# Altium schematic sheet [02] - Connectors.SchDoc("[02] - Connectors")
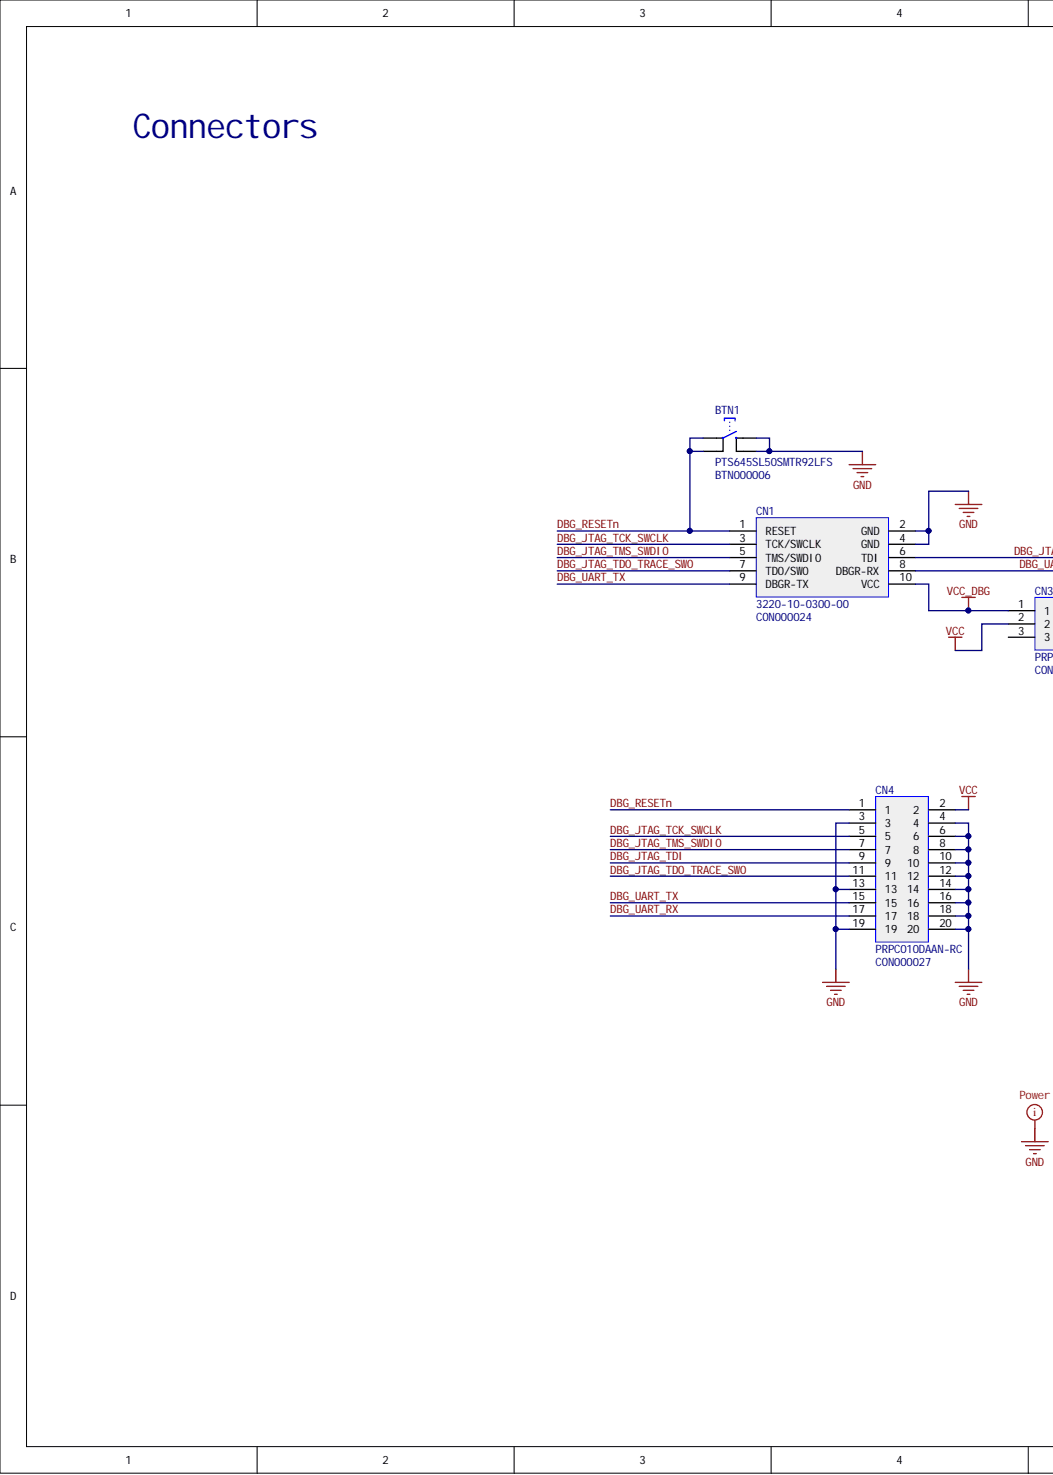
[diagram p0: [02] - Connectors.SchDoc, left]
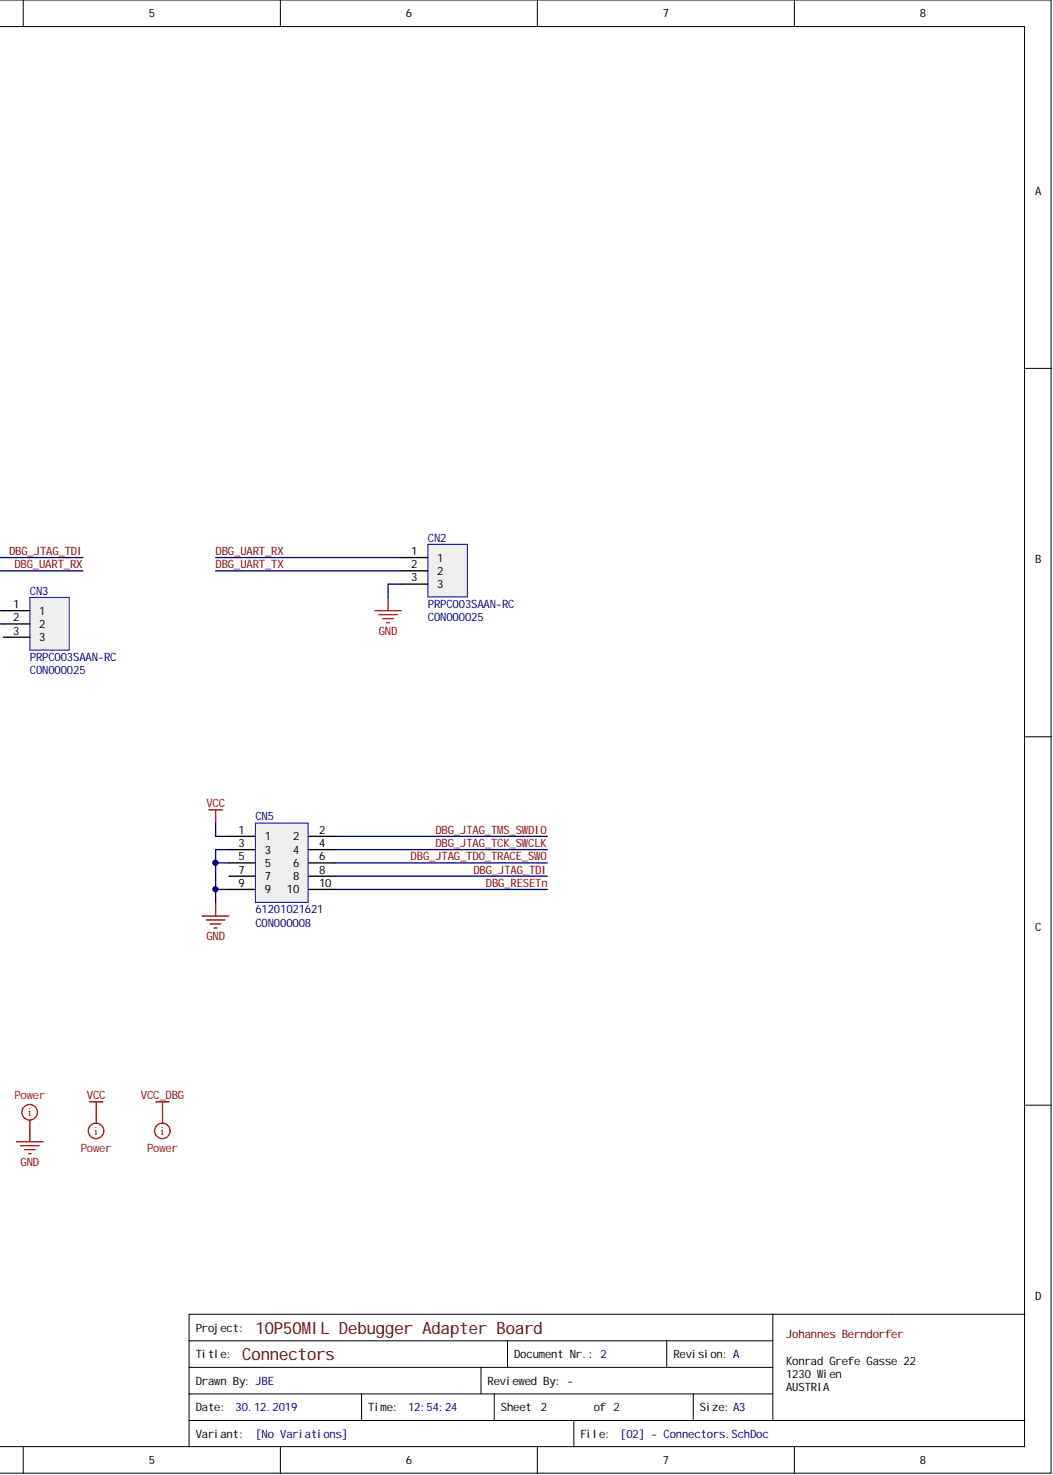
[diagram p1: [02] - Connectors.SchDoc, right]

## Parts
BTN1  PTS645SL50SMTR92LFS  Internal Part Number: BTN000006  [BTN-SMD-PTS645-CK]
CN1  3220-10-0300-00  [CON-SMD-PINHEADER-SHIELDED-1.27MM-10POS-2X5-3220-10-0300-00-CNC-TECH]
CN2  PRPC003SAAN-RC  [CON-THT-PINHEADER-2.54MM-3POS-1X3]
CN3  PRPC003SAAN-RC  [CON-THT-PINHEADER-2.54MM-3POS-1X3]
CN4  PRPC010DAAN-RC  [CON-THT-PINHEADER-2.54MM-20POS-2X10]
CN5  61201021621  [CON-THT-PINHEADER-SHIELDED-2.54MM-10POS-61201021621-WURTH]

## Nets
GND: BTN1.3 BTN1.4 CN1.2(GND) CN1.4(GND) CN2.3 CN4.3 CN4.4 CN4.6 CN4.8 CN4.10 CN4.12 CN4.13 CN4.14 CN4.16 CN4.18 CN4.19 CN4.20 CN5.3 CN5.5 CN5.9
VCC: CN3.2 CN4.2 CN5.1
VCC_DBG: CN1.10(VCC) CN3.1
DBG_JTAG_TCK_SWCLK: CN1.3(TCK/SWCLK) CN4.5 CN5.4
DBG_JTAG_TDI: CN1.6(TDI) CN4.9 CN5.8
DBG_JTAG_TDO_TRACE_SWO: CN1.7(TDO/SWO) CN4.11 CN5.6
DBG_JTAG_TMS_SWDIO: CN1.5(TMS/SWDIO) CN4.7 CN5.2
DBG_RESETn: BTN1.1 BTN1.2 CN1.1(RESET) CN4.1 CN5.10
DBG_UART_RX: CN1.8(DBGR-RX) CN2.1 CN4.17
DBG_UART_TX: CN1.9(DBGR-TX) CN2.2 CN4.15

Not connected: CN3 3; CN5 7

## Library data of the parts
BTN1 = PTS645SL50SMTR92LFS: BTN TACT SPST-NO 12V 50mA SMD BLACK; Manufacturer: ITT C&K; Throw Configuration: SPST; Switch Function: Off-Mom; Operating Force: 1.27N; Mechanical Life: 100000Cycles; Illumination Type: Non-Illuminated; Actuator Type: Standard; Actuator Material: Nylon,Polyamide; Voltage Rating (DC): 12V; Termination: Gull Wing; Supplier Part Number 1: CKN9088CT-ND; Supplier 1: Digi-Key; Stock 1: 127172; RoHS: Yes; REACH SVHC: No SVHC; Pricing 1: 1 = 0,31000, 10 = 0,30000, 25 = 0,29000, 50 = 0,27960, 100 = 0,26410, 250 = 0,24860, 500 = 0,23822 (USD); Packaging: Tape and Reel; Package Quantity: 1000; Orientation: Straight; Mount: Surface Mount; Min Operating Temperature: -20°C; Max Voltage Rating (DC): 12V; Max Operating Temperature: 60°C; Max Current Rating: 50mA; Manufacturer Part Number: PTS645SL50SMTR92LFS; Library Name: Altium_Lib_Standard.IntLib; Length: 6mm; Lead Free: Lead Free; Depth: 9mm; Current Rating: 50mA; Contact Plating: Silver; Contact Material: Bronze; ComponentLink6URL: https://datasheet.ciiva.com/46429/1839862-46429338.pdf?src-supplier=Element14; ComponentLink6Description: https://datasheet.ciiva.com/46429/1839862-46429338.pdf?src-supplier=Element14; ComponentLink5URL: https://datasheet.ciiva.com/46429/1839862-46429337.pdf?src-supplier=Element14; ComponentLink5Description: https://datasheet.ciiva.com/46429/1839862-46429337.pdf?src-supplier=Element14; ComponentLink4URL: https://datasheet.ciiva.com/14920/pts645-14920744.pdf?src-supplier=Digi-Key; ComponentLink4Description: https://datasheet.ciiva.com/14920/pts645-14920744.pdf?src-supplier=Digi-Key; ComponentLink3URL: https://datasheet.ciiva.com/14920/pts645-14920743.pdf?src-supplier=Digi-Key; ComponentLink3Description: https://datasheet.ciiva.com/14920/pts645-14920743.pdf?src-supplier=Digi-Key; ComponentLink2URL: https://datasheet.ciiva.com/14920/pts645-14920742.pdf?src-supplier=Digi-Key; ComponentLink2Description: https://datasheet.ciiva.com/14920/pts645-14920742.pdf?src-supplier=Digi-Key; ComponentLink1URL: https://datasheet.ciiva.com/26927/getdatasheetpartid-760859-26927133.pdf?src-supplier=Verical; ComponentLink1Description: https://datasheet.ciiva.com/26927/getdatasheetpartid-760859-26927133.pdf?src-supplier=Verical; Component Kind: Standard; Color: Black
CN1 = 3220-10-0300-00: CON PINHDR SMD 1.27MM SHIELDED KEYED CNC TECH 10POS; Internal Part Number: CON000024; Row Spacing: 0.05inch; Number of Positions: 10; Connector Type: Male Pin; Termination: Solder; Supplier Part Number 1: 1175-1629-ND; Supplier 1: Digi-Key; Stock 1: 107713; RoHS: Yes; Pricing 1: 1=0,74000, 10=0,69000, 100=0,52900, 500=0,46000, 3200=0,34500, 6400=0,33350, 12800=0,30590 (USD); Pitch: 0.05inch; Packaging: Tray; Package Quantity: 3200; Number of Rows: 2; Mount: Surface Mount; Manufacturer Part Number 1: 3220-10-0300-00; Manufacturer 1: CNC Tech; Library Name: Altium_Lib_Standard.IntLib; Contact Plating: Gold; ComponentLink1URL: https://datasheet.ciiva.com/18655/3220-xx-0300-00-18655365.pdf?src-supplier=Digi-Key; ComponentLink1Description: https://datasheet.ciiva.com/18655/3220-xx-0300-00-18655365.pdf?src-supplier=Digi-Key; Component Kind: Standard
CN2 CN3 = PRPC003SAAN-RC: CON PINHDR 2.54MM 1x03; Manufacturer: Sullins; Internal Part Number: CON000025; Pitch: 0.1inch; Number of Positions: 3; Connector Type: Male Pin; Termination: Solder; Supplier Part Number 1: S1011EC-03-ND; Supplier 1: Digi-Key; Stock 1: 16923; RoHS: Yes; Pricing 1: 1 = 0,12000, 10 = 0,10900, 25 = 0,08120, 50 = 0,06960, 100 = 0,06730, 250 = 0,05804, 500 = 0,05572 (USD); Packaging: Bulk; Package Quantity: 1; Number of Rows: 1; Mount: Through Hole; Manufacturer Part Number: PRPC003SAAN-RC; Library Name: Altium_Lib_Standard.IntLib; Contact Plating: Gold; Contact Mating Length: 0.23inch; ComponentLink1URL: https://datasheet.ciiva.com/741/xrxczzzsxxn-rc-st-11635-b-741242.pdf?src-supplier=Digi-Key; ComponentLink1Description: https://datasheet.ciiva.com/741/xrxczzzsxxn-rc-st-11635-b-741242.pdf?src-supplier=Digi-Key; Component Kind: Standard; Color: Black
CN4 = PRPC010DAAN-RC: CON PINHDR 2.54MM 2x10; Manufacturer: Sullins; Internal Part Number: CON000027; Row Spacing: 0.1inch; Number of Positions: 20; Connector Type: Male Pin; Termination: Solder; Supplier Part Number 1: S2011EC-10-ND; Supplier 1: Digi-Key; Stock 1: 2248; RoHS: Yes; Pricing 1: 1 = 0,50000, 10 = 0,46400, 25 = 0,41800, 50 = 0,37160, 100 = 0,35600, 250 = 0,32508, 500 = 0,30960 (USD); Pitch: 0.1inch; Packaging: Bulk; Package Quantity: 1; Number of Rows: 2; Mount: Through Hole; Manufacturer Part Number: PRPC010DAAN-RC; Library Name: Altium_Lib_Standard.IntLib; Contact Plating: Gold; Contact Mating Length: 0.23inch; ComponentLink1URL: https://datasheet.ciiva.com/741/xrxczzzdxxn-rc-11636-b-741275.pdf?src-supplier=Digi-Key; ComponentLink1Description: https://datasheet.ciiva.com/741/xrxczzzdxxn-rc-11636-b-741275.pdf?src-supplier=Digi-Key; Component Kind: Standard; Color: Black
CN5 = 61201021621: CON PINHDR 2.54MM SHIELDED 2x05 WURTH; Manufacturer: Wurth Electronics; Internal Part Number: CON000008; Voltage Rating: 250V; Row Spacing: 0.1inch; Number of Positions: 10; Insulation Resistance: 1GR; Gender: Male; Current Rating: 3A; Connector Type: Male Pin; Width: 20.36mm; Termination: Solder; Supplier Part Number 1: 732-2094-ND; Supplier 1: Digi-Key; Stock 1: 9556; RoHS: Yes; REACH SVHC: Unknown; Pricing 1: 1 = 0,45000, 10 = 0,43700, 50 = 0,40300, 100 = 0,36100, 260 = 0,31900, 520 = 0,31100 (USD); Pitch: 2.54mm; Packaging: Tray; Package Quantity: 260; Orientation: Straight; Number of Rows: 2; Number of Contacts: 10; Mount: Through Hole; Min Operating Temperature: -40°C; Max Operating Temperature: 125°C; Manufacturer Part Number: 61201021621; Library Name: Altium_Lib_Standard.IntLib; Length: 9.1mm; Lead Free: Lead Free; Housing Material: PBT; Height: 8.85mm; Flammability Rating: UL94 V-0; Contact Plating: Gold; Contact Material: Copper Alloy,Copper; Contact Gender: Male; ComponentLink3URL: https://datasheet.ciiva.com/7648/76595-7648121.pdf?src-supplier=Element14; ComponentLink3Description: https://datasheet.ciiva.com/7648/76595-7648121.pdf?src-supplier=Element14; ComponentLink2URL: https://datasheet.ciiva.com/15395/6120xx21621-265834-15395106.pdf?src-supplier=Mouser; ComponentLink2Description: https://datasheet.ciiva.com/15395/6120xx21621-265834-15395106.pdf?src-supplier=Mouser; ComponentLink1URL: https://datasheet.ciiva.com/731/6120xx21621-731344.pdf?src-supplier=Digi-Key; ComponentLink1Description: https://datasheet.ciiva.com/731/6120xx21621-731344.pdf?src-supplier=Digi-Key; Component Kind: Standard; Color: Black
